# Altium schematic sheet 05_RGB_LCD.SchDoc(05_RGB_LCD)
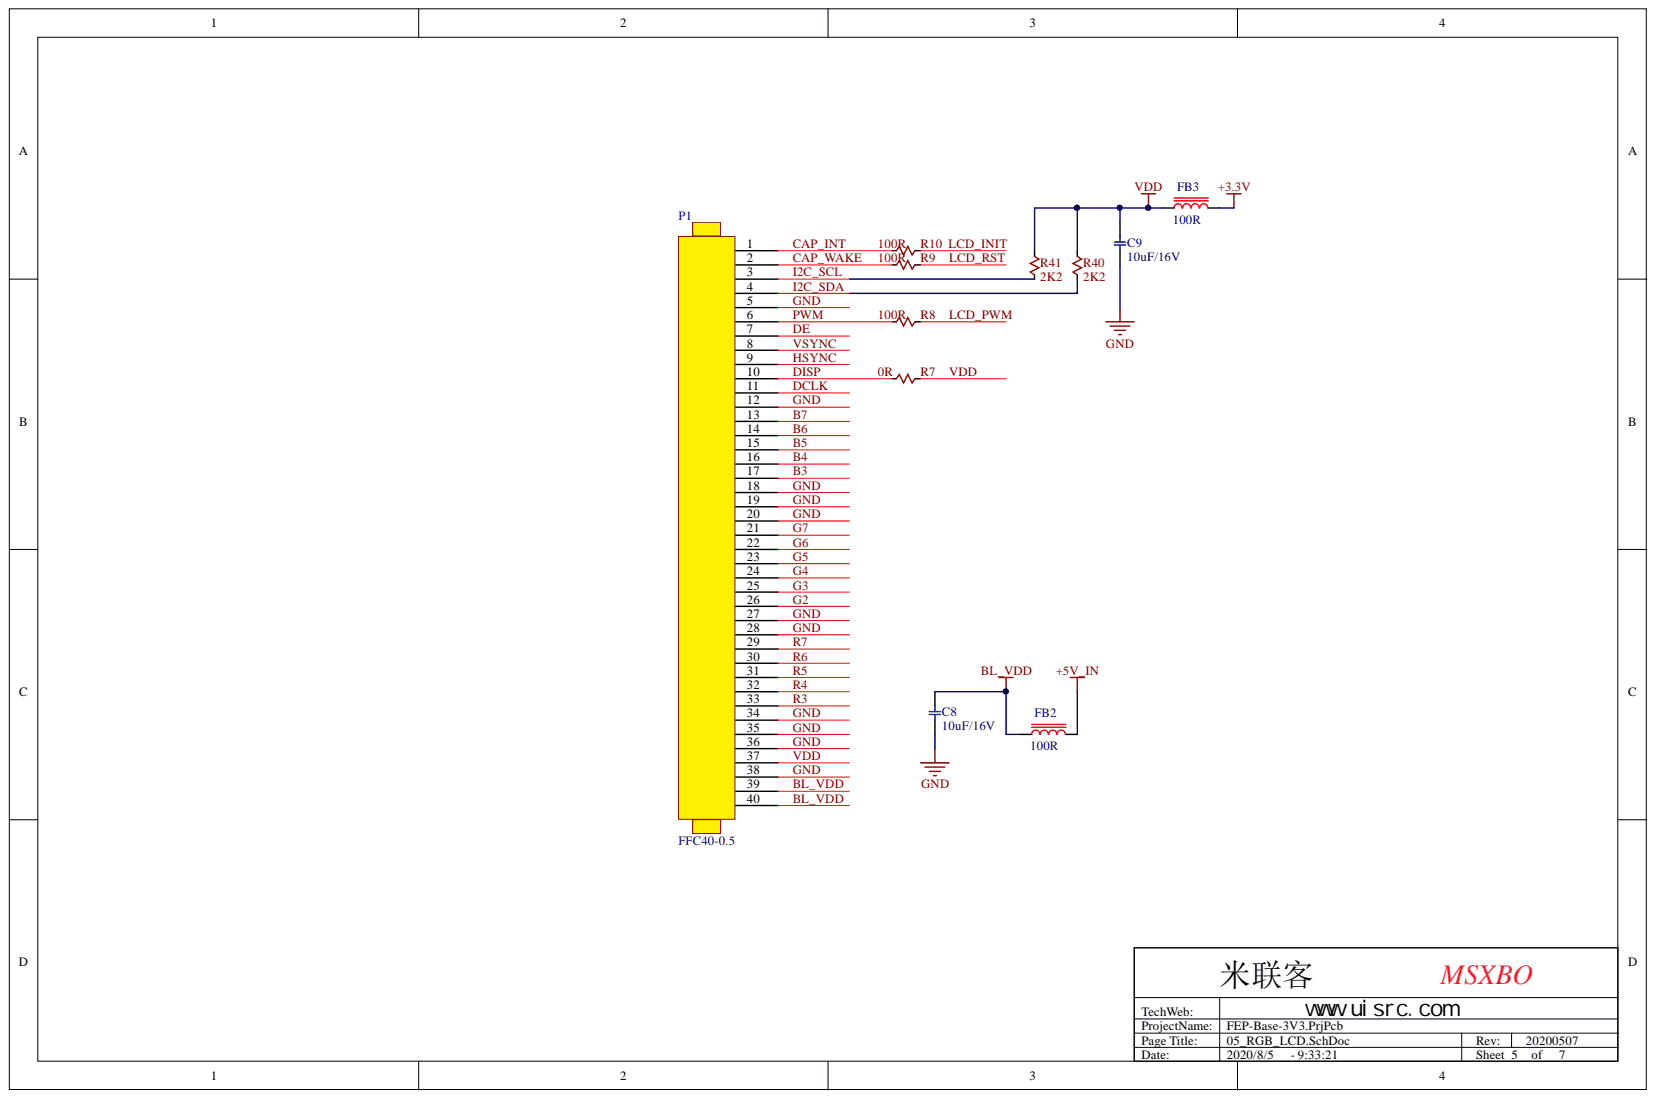
[diagram p0: 05_RGB_LCD.SchDoc]

## Parts
C8  10uF/16V  [cap0805]
C9  10uF/16V  [cap0805]
FB2  100R  [CAP0603]
FB3  100R  [CAP0603]
P1  FFC40-0.5  [FFC40-0.5]
R7  0R  [RES0402]
R8  100R  [RES0402]
R9  100R  [RES0402]
R10  100R  [RES0402]
R40  2K2  [RES0402]
R41  2K2  [RES0402]

## Nets
+3.3V: FB3.2
+5V_IN: FB2.2
B3: P1.17
B4: P1.16
B5: P1.15
B6: P1.14
B7: P1.13
BL_VDD: C8.2 FB2.1 P1.39 P1.40
CAP_INT: P1.1 R10.1
CAP_WAKE: P1.2 R9.1
DCLK: P1.11
DE: P1.7
DISP: P1.10 R7.1
G2: P1.26
G3: P1.25
G4: P1.24
G5: P1.23
G6: P1.22
G7: P1.21
GND: C8.1 C9.1 P1.5 P1.12 P1.18 P1.19 P1.20 P1.27 P1.28 P1.34 P1.35 P1.36 P1.38
HSYNC: P1.9
I2C_SCL: P1.3 R41.1
I2C_SDA: P1.4 R40.1
LCD_INIT: R10.2
LCD_PWM: R8.2
LCD_RST: R9.2
PWM: P1.6 R8.1
R3: P1.33
R4: P1.32
R5: P1.31
R6: P1.30
R7: P1.29
VDD: C9.2 FB3.1 P1.37 R7.2 R40.2 R41.2
VSYNC: P1.8

## Library data of the parts
C8 C9 = CAP_10uF/16V: Capacitor; Component Kind: Standard; Library Name: EnHom Frequently Used.SchLib
FB2 FB3 = Ferrite Bead: Generic Ferrite Bead; Value: 600; Component Kind: Standard; Library Name: Miz702N_fun.IntLib
R7-R10 = RESISTOR: Generic Resistor; Value: 390; Component Kind: Standard; Library Name: Miz702N_fun.IntLib
R40 R41 = RESISTOR: Generic Resistor; Component Kind: Standard; Library Name: Digilent.IntLib; Value: 2K2
P1 = FFC40-0.5: Component Kind: Standard; Library Name: FEP-Base-3V3.SCHLIB
